# Altium schematic sheet AnalogSignalPath.SchDoc(AnalogSignalPath)
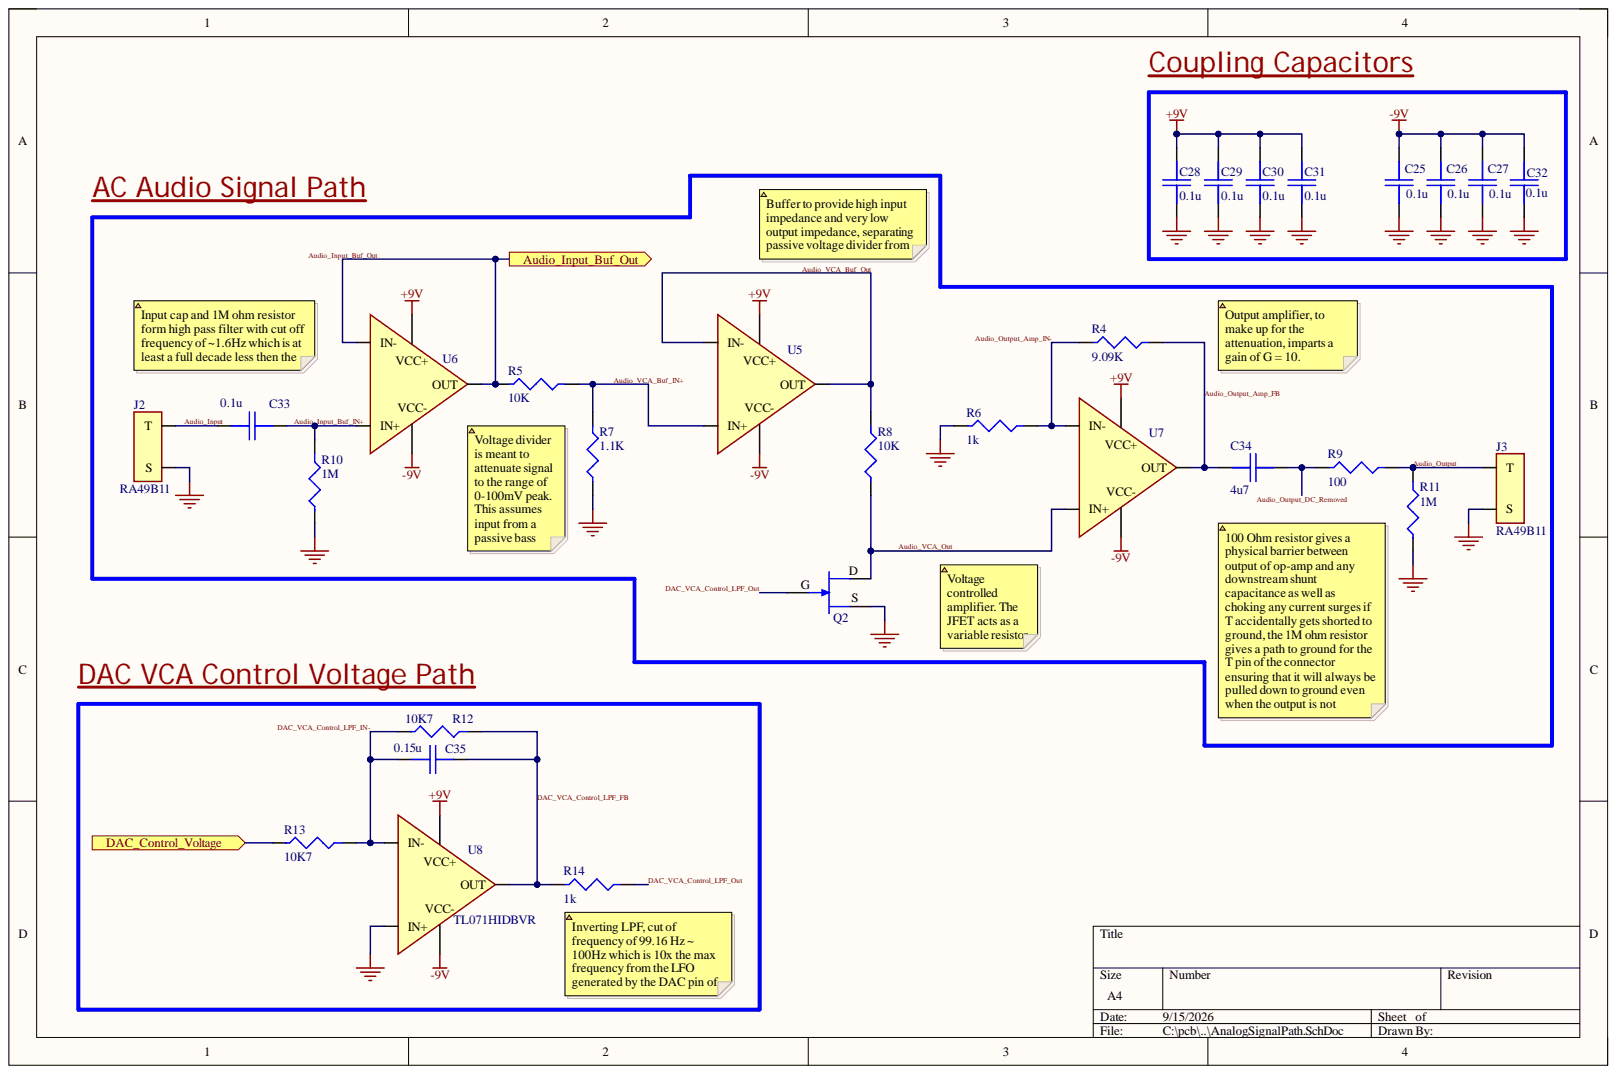
[diagram p0: AnalogSignalPath.SchDoc]

## Parts
C25  0.1u  [C0603]
C26  0.1u  [C0603]
C27  0.1u  [C0603]
C28  0.1u  [C0603]
C29  0.1u  [C0603]
C30  0.1u  [C0603]
C31  0.1u  [C0603]
C32  0.1u  [C0603]
C33  0.1u  [R82DC3100AA50K]
C34  4u7  [B32522C0475J000]
C35  0.15u  [R82EC3150SH70J]
J2  RA49B11  [RA49B11]
J3  RA49B11  [RA49B11]
Q2  MMBFJ113  [SOT23]  (value not drawn)
R4  9.09K  [R0603]
R5  10K  [R0603]
R6  1k  [R0603]
R7  1.1K  [R0805]
R8  10K  [R0603]
R9  100  [R0603]
R10  1M  [R0603]
R11  1M  [R0603]
R12  10K7  [R0603]
R13  10K7  [R0603]
R14  1k  [R0603]
U5  TL071HIDBVR  [TL071HIDBVR]
U6  TL071HIDBVR  [TL071HIDBVR]
U7  TL071HIDBVR  [TL071HIDBVR]
U8  TL071HIDBVR  [TL071HIDBVR]

## Ports
Audio_Input_Buf_Out, DAC_Control_Voltage

## Nets
+9V: C28.1 C29.1 C30.1 C31.1 U5.5 U6.5 U7.5 U8.5
-9V: C25.2 C26.2 C27.2 C32.2 U5.2 U6.2 U7.2 U8.2
GND: C25.1 C26.1 C27.1 C28.2 C29.2 C30.2 C31.2 C32.1 J2.2 J3.2 Q2.2 R6.1 R7.1 R10.1 R11.1 U8.3(IN+)
Audio_Input: C33.1 J2.1
Audio_Input_Buf_IN+: C33.2 R10.2 U6.3(IN+)
Audio_Input_Buf_Out: R5.1 U6.1(OUT) U6.4(IN-) ; port Audio_Input_Buf_Out
Audio_Output: J3.1 R9.2 R11.2
Audio_Output_Amp_FB: C34.1 R4.2 U7.1(OUT)
Audio_Output_Amp_IN-: R4.1 R6.2 U7.4(IN-)
Audio_Output_DC_Removed: C34.2 R9.1
Audio_VCA_Buf_IN+: R5.2 R7.2 U5.3(IN+)
Audio_VCA_Buf_Out: R8.2 U5.1(OUT) U5.4(IN-)
Audio_VCA_Out: Q2.1 R8.1 U7.3(IN+)
DAC_Control_Voltage: R13.1 ; port DAC_Control_Voltage
DAC_VCA_Control_LPF_FB: C35.2 R12.2 R14.1 U8.1(OUT)
DAC_VCA_Control_LPF_IN-: C35.1 R12.1 R13.2 U8.4(IN-)
DAC_VCA_Control_LPF_Out: Q2.3 R14.2
